# Altium schematic sheet RC96_sht3.SchDoc
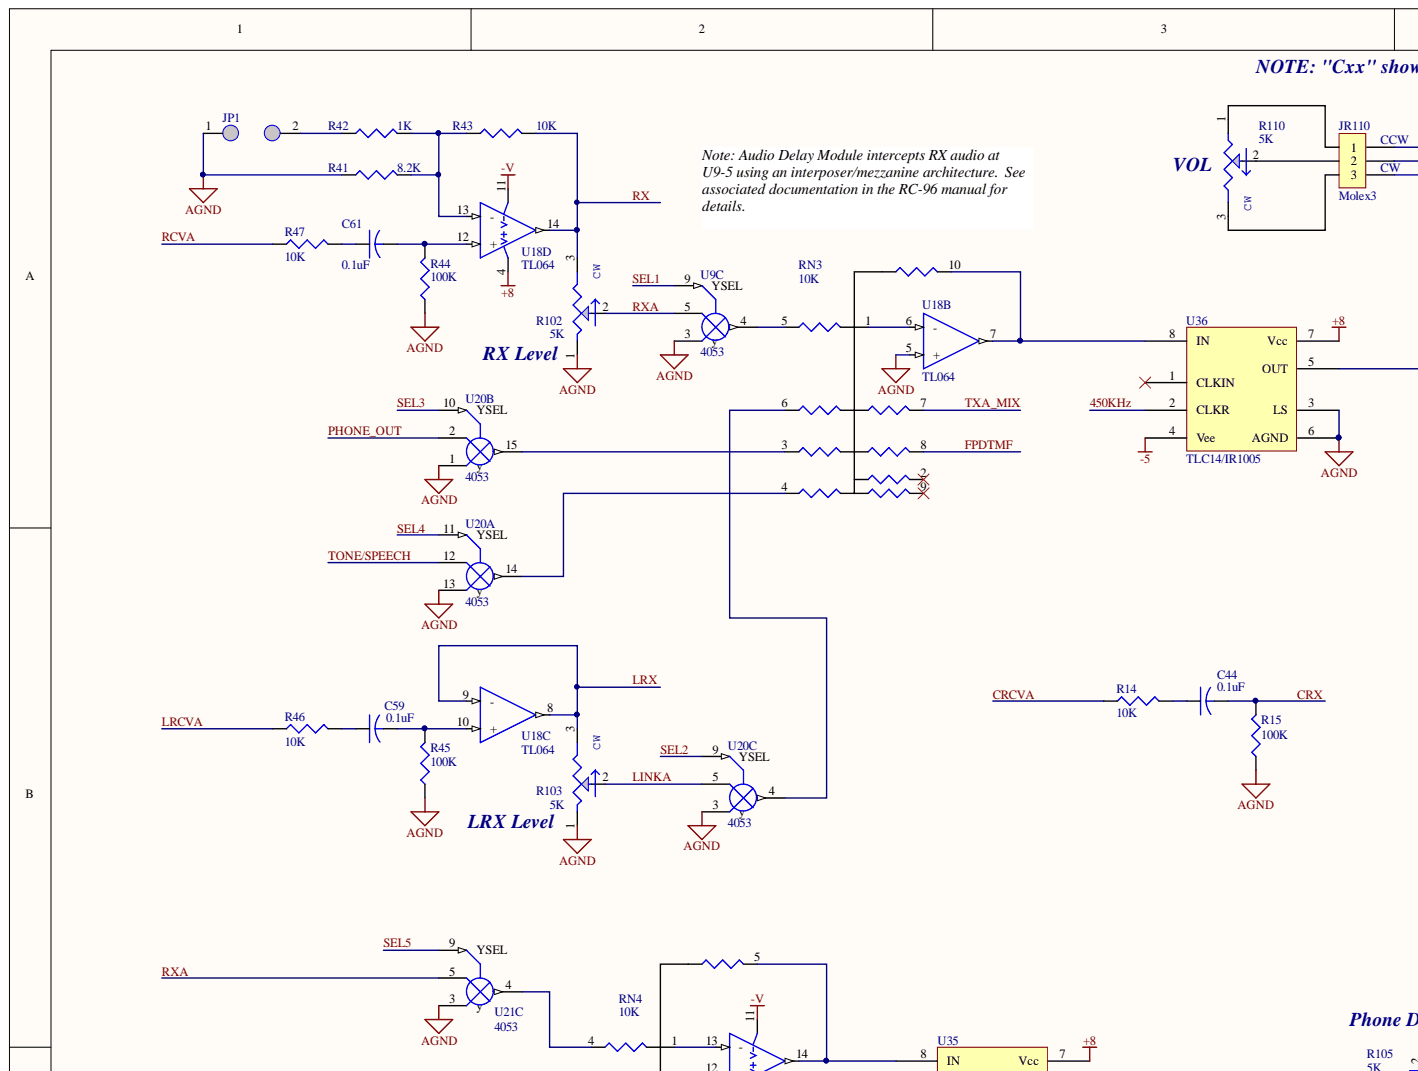
[diagram p0: RC96_sht3.SchDoc, top left]
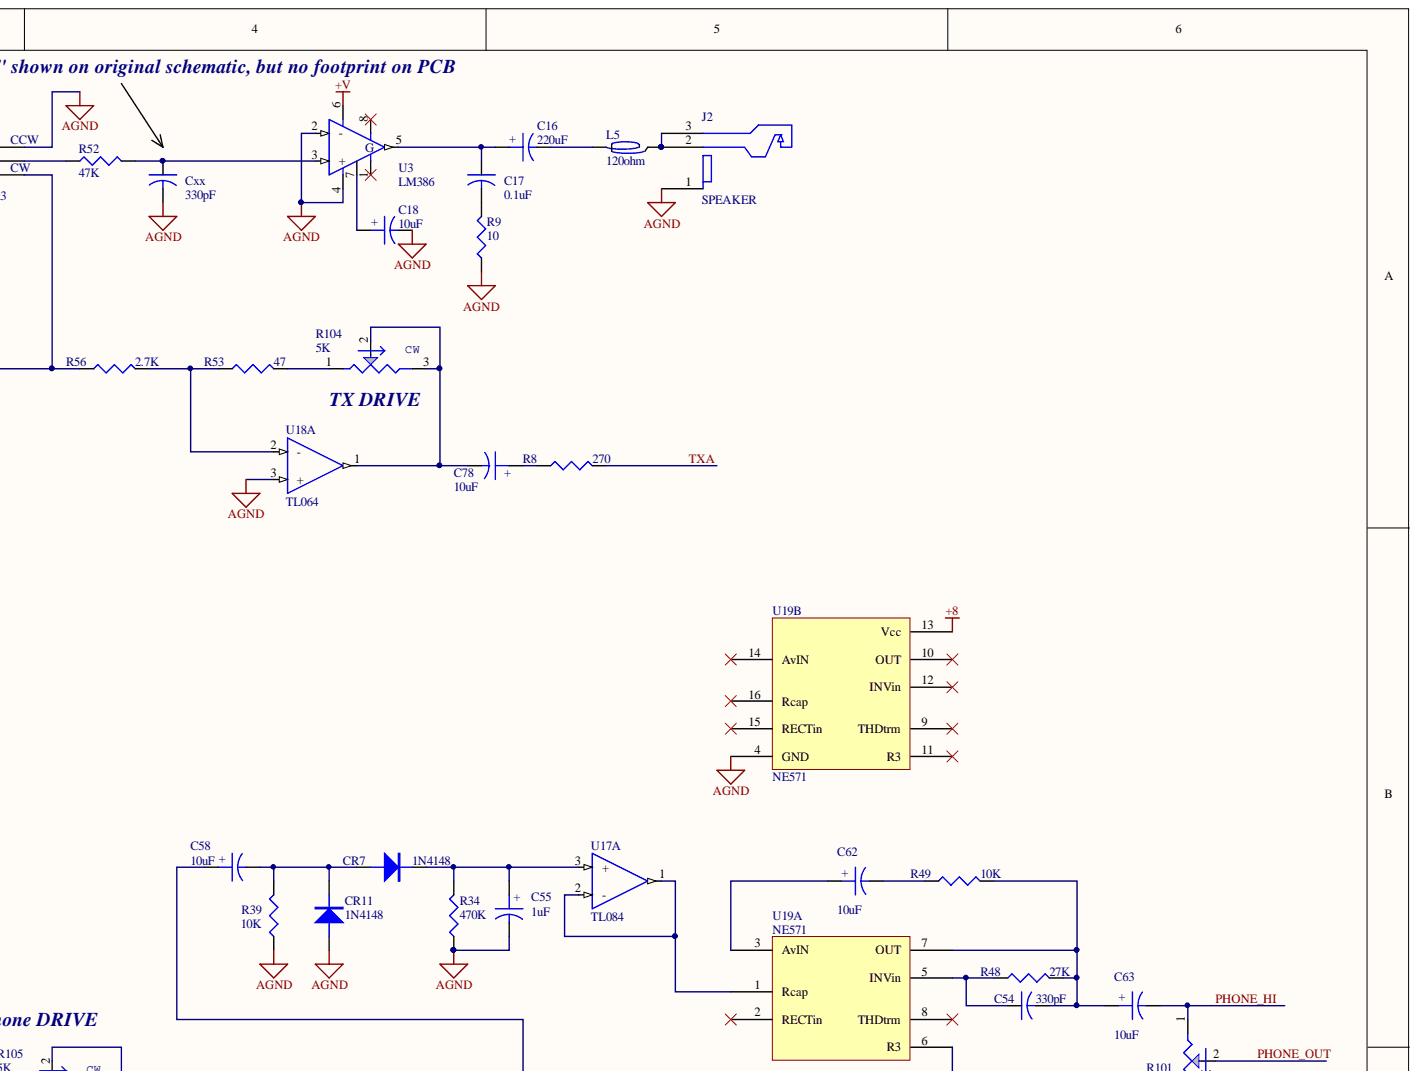
[diagram p1: RC96_sht3.SchDoc, top right]
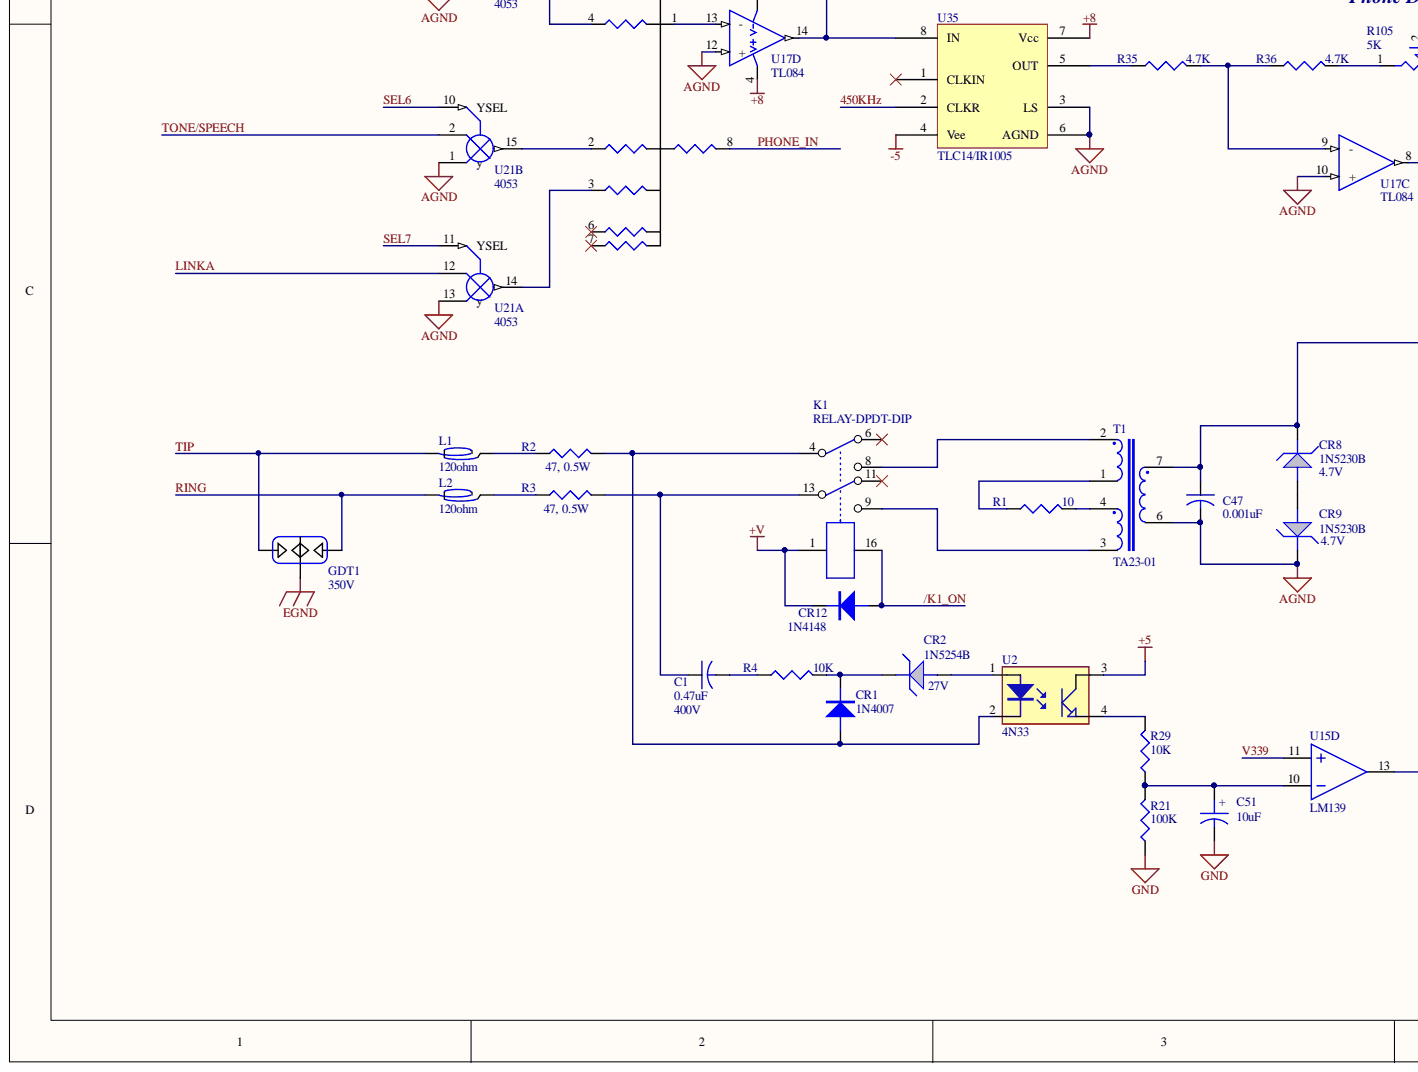
[diagram p2: RC96_sht3.SchDoc, bottom left]
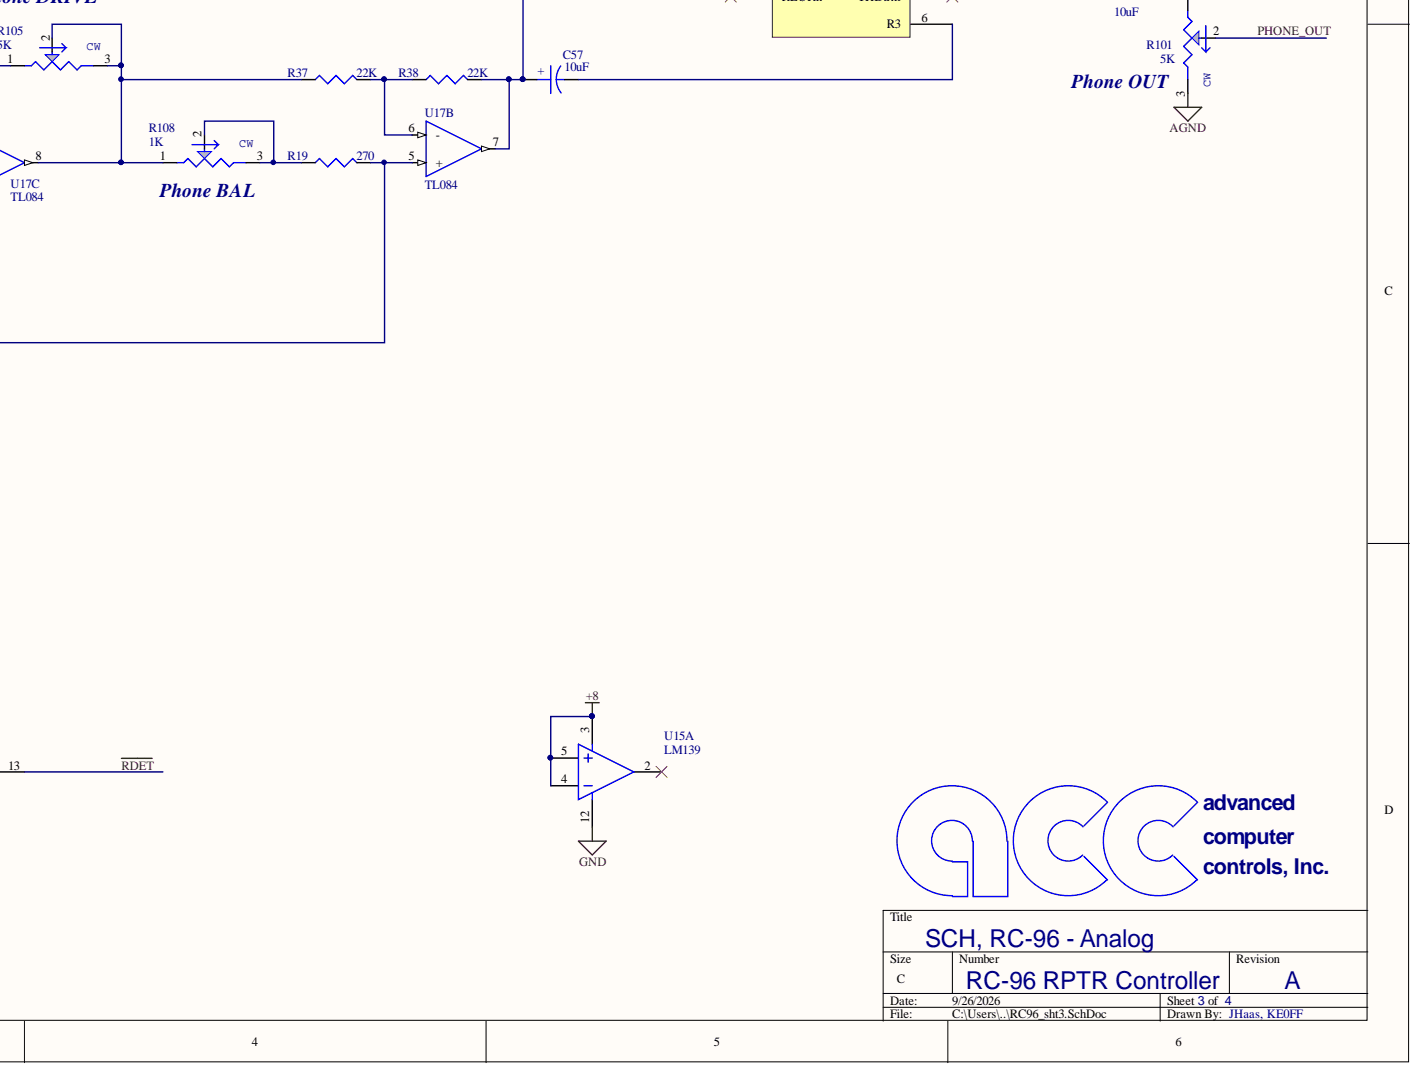
[diagram p3: RC96_sht3.SchDoc, bottom right]

## Parts
C1  0.47uF  VOLTAGE: 400V  [RAD10]
C16  220uF  [RB.2/.4]
C17  0.1uF  [RAD2]
C18  10uF  [RB.05/.15]
C44  0.1uF  [RAD2]
C47  0.001uF  [RAD2]
C51  10uF  [RB.05/.15]
C54  330pF  [RAD2]
C55  1uF  [RB.2/.4]
C57  10uF  [RB.05/.15]
C58  10uF  [RB.05/.15]
C59  0.1uF  [RAD2]
C61  0.1uF  [RAD2]
C62  10uF  [RB.05/.15]
C63  10uF  [RB.05/.15]
C78  10uF  [RB.05/.15]
CR1  1N4007  [AXIAL4]
CR2  1N5254B  NOTES: 27V  [AXIAL3]
CR7  1N4148  [AXIAL3]
CR8  1N5230B  NOTES: 4.7V  [AXIAL3]
CR9  1N5230B  NOTES: 4.7V  [AXIAL3]
CR11  1N4148  [AXIAL3]
CR12  1N4148  [AXIAL3]
Cxx  330pF  [RAD2]
GDT1  350V  [GDT3]
J2  SPEAKER
JP1  JUMPER_2POS  [CON_1X2]  (value not drawn)
JR110  Molex3  [HDR1X3]
K1  RELAY-DPDT-DIP  [DIP16]
L1  120ohm  [AXIAL10]
L2  120ohm  [AXIAL10]
L5  120ohm  [AXIAL10]
R1  10  [AXIAL4]
R2  47, 0.5W  [AXIAL6]
R3  47, 0.5W  [AXIAL6]
R4  10K  [AXIAL4]
R8  270  [AXIAL4]
R9  10  [AXIAL4]
R14  10K  [AXIAL4]
R15  100K  [AXIAL4]
R19  270  [AXIAL4]
R21  100K  [AXIAL4]
R29  10K  [AXIAL4]
R34  470K  [AXIAL4]
R35  4.7K  [AXIAL4]
R36  4.7K  [AXIAL4]
R37  22K  [AXIAL4]
R38  22K  [AXIAL4]
R39  10K  [AXIAL4]
R41  8.2K  [AXIAL4]
R42  1K  [AXIAL4]
R43  10K  [AXIAL4]
R44  100K  [AXIAL4]
R45  100K  [AXIAL4]
R46  10K  [AXIAL4]
R47  10K  [AXIAL4]
R48  27K  [AXIAL4]
R49  10K  [AXIAL4]
R52  47K  [AXIAL4]
R53  47  [AXIAL4]
R56  2.7K  [AXIAL4]
R101  5K  [TRI3]
R102  5K  [TRI3]
R103  5K  [TRI3]
R104  5K  [TRI3]
R105  5K  [TRI3]
R108  1K  [TRI3]
R110  5K  [PNL_MT]
RN3  10K  [SIP10]
RN4  10K  [SIP8]
T1  TA23-01  [PLIXFMR]
U2  4N33  [DIP4]
U3  LM386  [DIP8 - duplicate]
U9  4053  [DIP16]  (part C)
U15  LM139  [SO14]  (parts A,D)
U17  TL084  [DIP14]  (parts A,B,C,D)
U18  TL064  [DIP14]  (parts A,B,C,D)
U19  NE571  [DIP16]  (parts A,B)
U20  4053  [DIP16]  (parts A,B,C)
U21  4053  [DIP16]  (parts A,B,C)
U35  TLC14/IR1005  [DIP8]
U36  TLC14/IR1005  [DIP8]

## Nets
+5: U2.3
+8: U15.3 U15.4 U15.5 U17.4 U18.4 U19.13(Vcc) U35.7(Vcc) U36.7(Vcc)
+V: CR12.1 K1.1 U3.6
-5: U35.4(Vee) U36.4(Vee)
-V: U17.11 U18.11
AGND: C18.2 C47.2 C55.2 CR9.1 CR11.2 Cxx.2 J2.1 JP1.1 JR110.1 R9.1 R15.1 R34.1 R39.1 R41.1 R44.1 R45.1 R101.3 R102.1 R103.1 T1.6 U3.2(-) U3.4(+) U9.3(y) U17.10(+) U17.12(+) U18.3(+) U18.5(+) U19.4(GND) U20.1(y) U20.3(y) U20.13(y) U21.1(y) U21.3(y) U21.13(y) U35.3(LS) U35.6(AGND) U36.3(LS) U36.6(AGND)
EGND: GDT1.2
GND: C51.2 R21.1 U15.12
450KHZ: U35.2(CLKR) U36.2(CLKR)
/K1_ON: CR12.2 K1.16
CRCVA: R14.1
CRX: C44.2 R15.2
FPDTMF: RN3.8
LINKA: R103.2 U20.5 U21.12
LRCVA: R46.1
LRX: R103.3 U18.8 U18.9(-)
PHONE_HI: C63.2 R101.1
PHONE_IN: RN4.8
PHONE_OUT: R101.2 U20.2
~{RDET}: U15.13
RCVA: R47.1
RING: GDT1.1 L2.1
RX: R43.2 R102.3 U18.14
RXA: R102.2 U9.5 U21.5
SEL1: U9.9(YSEL)
SEL2: U20.9(YSEL)
SEL3: U20.10(YSEL)
SEL4: U20.11(YSEL)
SEL5: U21.9(YSEL)
SEL6: U21.10(YSEL)
SEL7: U21.11(YSEL)
TIP: GDT1.2 L1.1
TONE/SPEECH: U20.12 U21.2
TXA: R8.2
TXA_MIX: RN3.7
V339: U15.11
NetC1_1: C1.1 K1.13 R3.2
NetC1_2: C1.2 R4.1
NetC16_1: C16.1 C17.1 U3.5
NetC16_2: C16.2 L5.1
NetC17_2: C17.2 R9.2
NetC18_1: C18.1 U3.7
NetC44_1: C44.1 R14.2
NetC47_1: C47.1 CR8.1 R19.2 T1.7 U17.5(+)
NetC51_1: C51.1 R21.2 R29.1 U15.10
NetC54_1: C54.1 R48.1 U19.5(INVin)
NetC54_2: C54.2 C63.1 R48.2 R49.2 U19.7(OUT)
NetC55_1: C55.1 CR7.1 R34.2 U17.3(+)
NetC57_1: C57.1 C58.1 R38.2 U17.7
NetC57_2: C57.2 U19.6(R3)
NetC58_2: C58.2 CR7.2 CR11.1 R39.2
NetC59_1: C59.1 R46.2
NetC59_2: C59.2 R45.2 U18.10(+)
NetC61_1: C61.1 R47.2
NetC61_2: C61.2 R44.2 U18.12(+)
NetC62_1: C62.1 U19.3(AvIN)
NetC62_2: C62.2 R49.1
NetC78_1: C78.1 R8.1
NetC78_2: C78.2 R104.2 R104.3 U18.1
NetCR1_1: CR1.1 CR2.1 R4.2
NetCR1_2: CR1.2 K1.4 R2.2 U2.2
NetCR2_2: CR2.2 U2.1
NetCR8_2: CR8.2 CR9.2
NetCxx_1: Cxx.1 R52.2 U3.3
NetJ2_2: J2.2 J2.3 L5.2
NetJP1_2: JP1.2 R42.1
NetJR110_2: JR110.2 R52.1
NetJR110_3: JR110.3 R56.1 U36.5(OUT)
NetK1_8: K1.8 T1.2
NetK1_9: K1.9 T1.3
NetL1_2: L1.2 R2.1
NetL2_2: L2.2 R3.1
NetR1_1: R1.1 T1.1
NetR1_2: R1.2 T1.4
NetR19_1: R19.1 R108.2 R108.3
NetR29_2: R29.2 U2.4
NetR35_1: R35.1 U35.5(OUT)
NetR35_2: R35.2 R36.1 U17.9(-)
NetR36_2: R36.2 R105.1
NetR37_1: R37.1 R105.2 R105.3 R108.1 U17.8
NetR37_2: R37.2 R38.1 U17.6(-)
NetR41_2: R41.2 R42.2 R43.1 U18.13(-)
NetR53_1: R53.1 R56.2 U18.2(-)
NetR53_2: R53.2 R104.1
NetRN3_1: RN3.1 U18.6(-)
NetRN3_3: RN3.3 U20.15
NetRN3_4: RN3.4 U20.14
NetRN3_5: RN3.5 U9.4
NetRN3_6: RN3.6 U20.4
NetRN3_10: RN3.10 U18.7 U36.8(IN)
NetRN4_1: RN4.1 U17.13(-)
NetRN4_2: RN4.2 U21.15
NetRN4_3: RN4.3 U21.14
NetRN4_4: RN4.4 U21.4
NetRN4_5: RN4.5 U17.14 U35.8(IN)
NetU17_1: U17.1 U17.2(-) U19.1(Rcap)

Not connected: K1 6 11; R110 1 2 3; RN3 2 9; RN4 6 7; U3 1(G) 8; U15 2; U19 2(RECTin) 8(THDtrm) 9(THDtrm) 10(OUT) 11(R3) 12(INVin) 14(AvIN) 15(RECTin) 16(Rcap); U35 1(CLKIN); U36 1(CLKIN)

## Library data of the parts
C1 C17 C44 C47 C54 C59 C61 Cxx = CAP 0805: CAP, TANT, 0805, 50V, 1uF; ALT-MFR: -; ALT-MPN: -; Component Kind: Standard; DNP: -; MFR: -; MPN: -; Library Name: Galaxy SCH-jem-1.08.SchLib
C16 C18 C51 C55 C57 C58 C62 C63 C78 = CAP ELECTROLYTIC: ALT-MPN: -; Component Kind: Standard; DNP: -; MFR: -; MPN: -; NOTES: -; NOTES2: -; PRI-MPN: -; Library Name: Galaxy SCH-jem-1.08.SchLib
CR1 CR7 CR11 CR12 = DIODE-AXIAL: Diode; ALT-MPN: -; Component Kind: Standard; DNP: -; MFR: -; MPN: -; NOTES: -; NOTES2: -; PRI-MPN: -; Library Name: Galaxy SCH-jem-1.08.SchLib
CR2 CR8 CR9 = DIODE-ZENER: DIODE, ZENER; ALT-MPN: -; Component Kind: Standard; DNP: -; MFR: -; MPN: -; NOTES2: -; PRI-MPN: -; Library Name: Galaxy SCH-jem-1.08.SchLib
GDT1 = SPARK_SUPR_3PIN: SPARK-SUPR, GAS, 3-TERM, TH, 350V; ALT-MPN: -; Component Kind: Standard; DNP: -; MFR: -; MPN: -; NOTES: -; NOTES2: -; PRI-MPN: -; Library Name: Galaxy SCH-jem-1.08.SchLib
J2 = CONN_ MONO_1SW: JACK, MONO, 1SW; MFR: ??; ALT-MPN: -; Component Kind: Standard; DNP: -; MPN: ??; NOTES: -; NOTES2: -; PRI-MPN: -; Library Name: Galaxy SCH-jem-1.08.SchLib
JP1 = JUMPER_2POS: CON, JMPR, 2-PIN; ALT-MPN: -; Component Kind: Standard; DNP: -; MFR: -; MPN: -; NOTES: -; NOTES2: -; PRI-MPN: -; Library Name: Galaxy SCH-jem-1.08.SchLib
JR110 = CON3: Connector, 3 pin; ALT-MPN: -; Component Kind: Standard; DNP: -; MFR: -; MPN: -; NOTES: -; NOTES2: -; PRI-MPN: -; Library Name: Galaxy SCH-jem-1.08.SchLib
K1 = RELAY-DPDT-DIP: RLY, DPDT, DIP; ALT-MPN: -; Component Kind: Standard; DNP: -; MFR: -; MPN: -; NOTES: -; NOTES2: -; PRI-MPN: -; Library Name: Galaxy SCH-jem-1.08.SchLib
L1 L2 L5 = FB2: BD, FRT, TH, 2LOOP; ALT-MPN: -; Component Kind: Standard; DNP: -; MFR: -; MPN: -; NOTES: -; NOTES2: -; PRI-MPN: -; Library Name: Galaxy SCH-jem-1.08.SchLib
R1-R4 R8 R9 R14 R15 R19 R21 R29 R34-R39 R41-R49 R52 R53 R56 = R0805: RES, CHP, 0201, 1%, 4.7K; ALT-MPN: -; Component Kind: Standard; DNP: -; MFR: -; MPN: -; NOTES: -; NOTES2: -; PRI-MPN: -; Library Name: Galaxy SCH-jem-1.08.SchLib
R101-R105 = RESISTOR VAR: RES, VAR, R/A; ALT-MPN: -; Component Kind: Standard; DNP: -; MFR: -; MPN: -; NOTES: -; NOTES2: -; PRI-MPN: -; Library Name: Galaxy SCH-jem-1.08.SchLib
R108 R110 = RESISTOR VAR: RES, VAR; ALT-MPN: -; Component Kind: Standard; DNP: -; MFR: -; MPN: -; NOTES: -; NOTES2: -; PRI-MPN: -; Library Name: Galaxy SCH-jem-1.08.SchLib
RN3 = RP9_MIX: RES, NET, SIP, 9RES; ALT-MPN: -; Component Kind: Standard; DNP: -; MFR: -; MPN: -; NOTES: -; NOTES2: -; PRI-MPN: -; Library Name: Galaxy SCH-jem-1.08.SchLib
RN4 = RP7_MIX: RES, NET, SIP, 9RES; ALT-MPN: -; Component Kind: Standard; DNP: -; MFR: -; MPN: -; NOTES: -; NOTES2: -; PRI-MPN: -; Library Name: Galaxy SCH-jem-1.08.SchLib
T1 = XFMR_PLI: XFMR, PLI, 600ohm; ALT-MPN: -; Component Kind: Standard; DNP: -; MFR: -; MPN: -; NOTES: -; NOTES2: -; PRI-MPN: -; Library Name: Galaxy SCH-jem-1.08.SchLib
U2 = OPTO_ISO: ISO, OPTO, NPN, SNGL; ALT-MPN: -; Component Kind: Standard; DNP: -; MFR: -; MPN: -; NOTES: -; NOTES2: -; PRI-MPN: -; Library Name: Galaxy SCH-jem-1.08.SchLib
U3 = LM386: IC, AMP, SNGL, SPKR, 8ohm; MFR: TI; MPN: LM386N-3/NOPB; ALT-MPN: -; Component Kind: Standard; DNP: -; NOTES: -; NOTES2: -; PRI-MPN: -; Library Name: Galaxy SCH-jem-1.08.SchLib
U9 U20 U21 = 4053_ALT: IC, SW, ANALOG, TRI; ALT-MPN: -; Component Kind: Standard; DNP: -; MFR: -; MPN: -; NOTES: -; NOTES2: -; PRI-MPN: -; Library Name: Galaxy SCH-jem-1.08.SchLib
U15 = LM139: IC, OPAMP, QUAD; ALT-MPN: -; Component Kind: Standard; DNP: -; MFR: -; MPN: -; NOTES: -; NOTES2: -; PRI-MPN: -; Library Name: Galaxy SCH-jem-1.08.SchLib
U17 = TL084: IC, OPAMP, JFET, QUAD; ALT-MPN: -; Component Kind: Standard; DNP: -; MFR: -; MPN: -; NOTES: -; NOTES2: -; PRI-MPN: -; Library Name: Galaxy SCH-jem-1.08.SchLib
U18 = TL084: IC, OPAMP, JFET, QUAD; MFR: TI; ALT-MPN: -; Component Kind: Standard; DNP: -; MPN: TL064; NOTES: -; NOTES2: -; PRI-MPN: -; Library Name: Galaxy SCH-jem-1.08.SchLib
U19 = NE571: IC, AGC, DUAL; MFR: Signetics; Component Kind: Standard; DNP: -; MPN: NE571; Library Name: Galaxy SCH-jem-1.08.SchLib
U35 U36 = TLC14: IC, FILT, SW-CAP, DIV100; MFR: TI; Component Kind: Standard; DNP: -; MPN: TLC14; Library Name: Galaxy SCH-jem-1.08.SchLib
